# Altium schematic sheet BatGPSTracker.SchDoc
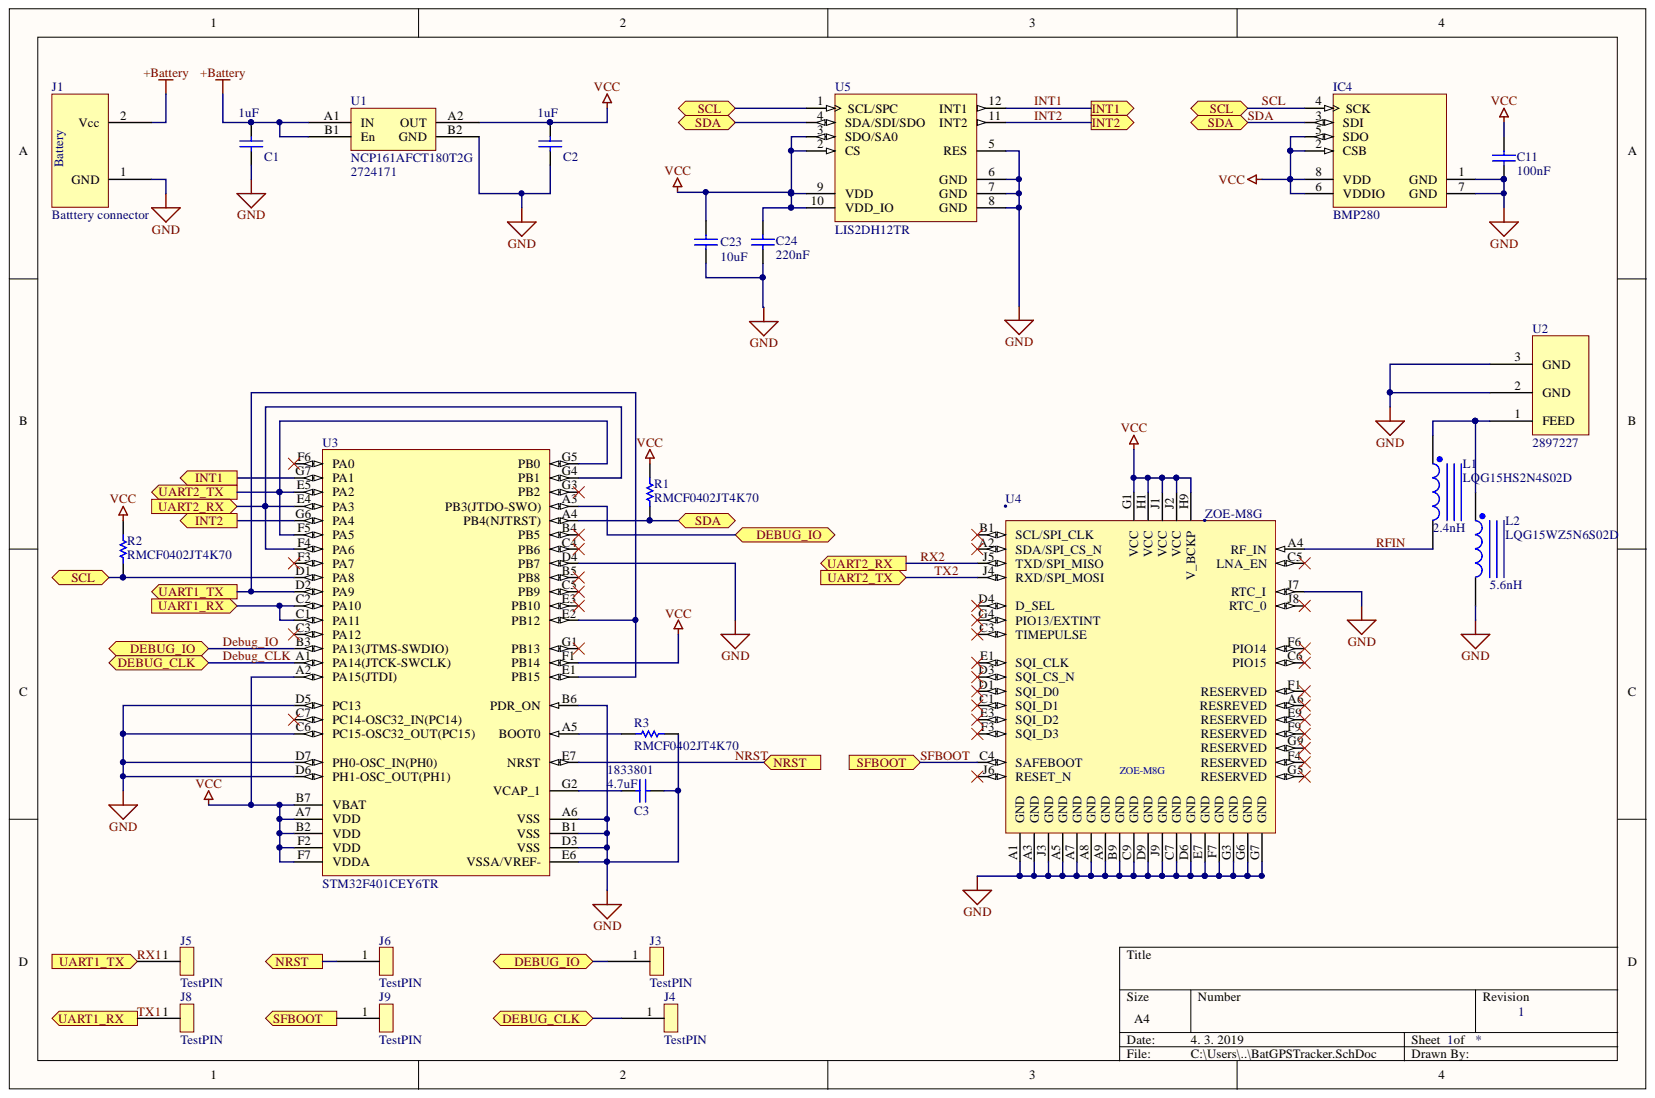
[diagram p0: BatGPSTracker.SchDoc]

## Parts
C1  value 1uF  [CAPC0402(1005)60_L]  (hidden comment: CAP 1uF 10V 0402(1005))
C2  value 1uF  [CAPC0402(1005)60_L]  (hidden comment: CAP 1uF 10V 0402(1005))
C3  value 4.7uF  Supplier Part Number 1: 1833801  [CAPC0402(1005)60_L]  (hidden comment: CAP 4.7uF 16V 0402(1005))
C11  value 100nF  [CAPC0402(1005)60_L]  (hidden comment: CAP 100nF 25V 0402(1005))
C23  value 10uF  [CAPC0402(1005)60_L]  (hidden comment: CAP 10uF 6.3V 0402(1005))
C24  value 220nF  [CAPC0402(1005)60_L]  (hidden comment: CAP 220nF 6.3V 0402(1005))
IC4  BMP280  [BOSC-LGA-8_V]
J1  Batttery connector  [PCBCOMPONENT_1]  (no BOM)
J3  TestPIN  (no BOM)
J4  TestPIN  (no BOM)
J5  TestPIN  (no BOM)
J6  TestPIN  (no BOM)
J8  TestPIN  (no BOM)
J9  TestPIN  (no BOM)
L1  LQG15HS2N4S02D  Inductance: 2.4nH  [INDC1005X55X25ML05T10_A]
L2  LQG15WZ5N6S02D  Inductance: 5.6nH  [INDC1005X55X25ML05T10_A]
R1  RMCF0402JT4K70  [RESC1005X40X25LL10T15]
R2  RMCF0402JT4K70  [RESC1005X40X25LL10T15]
R3  RMCF0402JT4K70  [RESC1005X40X25LL10T15]
U1  NCP161AFCT180T2G  Supplier Part Number 1: 2724171  [BGA4C40P2X2_84X84X60N]
U2  Supplier Part Number 1: 2897227  [W3011]  (hidden comment: W3011)
U3  STM32F401CEY6TR  [STM-WLCSP49-3060X3060DE_V]
U4  ZOE-M8G  [ZOE-M8_ZOE-M8G(Primary)]
U5  LIS2DH12TR  [STM-LGA-12]

## Ports
DEBUG_CLK, DEBUG_IO, INT1, INT2, NRST, SCL, SDA, SFBOOT, UART1_RX, UART1_TX, UART2_RX, UART2_TX

## Nets
+BATTERY: C1.2 J1.2 U1.A1(IN) U1.B1(En)
GND: C1.1 C2.1 C3.2 C11.1 C23.1 C24.1 IC4.1(GND) IC4.7(GND) J1.1 L2.2 R3.1 U1.B2(GND) U2.2 U2.3 U3.A6(VSS) U3.B1(VSS) U3.B6(PDR_ON) U3.C6(PC15-OSC32_OUT(PC15)) U3.D3(VSS) U3.D4(PB7) U3.D5(PC13) U3.D6(PH1-OSC_OUT(PH1)) U3.D7(PH0-OSC_IN(PH0)) U3.E6(VSSA/VREF-) U4.A1(GND) U4.A3(GND) U4.A5(GND) U4.A7(GND) U4.A8(GND) U4.A9(GND) U4.B9(GND) U4.C7(GND) U4.C9(GND) U4.D6(GND) U4.D9(GND) U4.E7(GND) U4.F7(GND) U4.G3(GND) U4.G6(GND) U4.G7(GND) U4.J3(GND) U4.J7(RTC_I) U4.J9(GND) U5.5(RES) U5.6(GND) U5.7(GND) U5.8(GND)
VCC: C2.2 C11.2 C23.2 C24.2 IC4.2(CSB) IC4.5(SDO) IC4.6(VDDIO) IC4.8(VDD) R1.2 R2.2 U1.A2(OUT) U3.A2(PA15(JTDI)) U3.A7(VDD) U3.B2(VDD) U3.B7(VBAT) U3.F1(PB14) U3.F2(VDD) U3.F7(VDDA) U4.G1(VCC) U4.J1(VCC) U4.J2(VCC) U5.2(CS) U5.3(SDO/SA0) U5.9(VDD) U5.10(VDD_IO)
DEBUG_CLK: J4.1 U3.A1(PA14(JTCK-SWCLK)) ; port DEBUG_CLK
DEBUG_IO: J3.1 U3.A3(PB3(JTDO-SWO)) U3.B3(PA13(JTMS-SWDIO)) ; port DEBUG_IO
INT1: U3.G7(PA1) U5.12(INT1) ; port INT1
INT2: U3.G6(PA4) U5.11(INT2) ; port INT2
NRST: J6.1 U3.E7(NRST) ; port NRST
RFIN: L1.2 U4.A4(RF_IN)
RX1: J5.1 U3.D2(PA9) U3.E1(PB15) U3.E2(PB12) ; port UART1_TX
RX2: U3.E4(PA3) U3.F4(PA6) U3.G4(PB1) U4.J5(TXD/SPI_MISO) ; port UART2_RX
SCL: IC4.4(SCK) R2.1 U3.D1(PA8) U5.1(SCL/SPC) ; port SCL
SDA: IC4.3(SDI) R1.1 U3.A4(PB4(NJTRST)) U5.4(SDA/SDI/SDO) ; port SDA
SFBOOT: J9.1 U4.C4(SAFEBOOT) ; port SFBOOT
TX1: J8.1 U3.C1(PA11) U3.C2(PA10) ; port UART1_RX
UART2_TX: U3.E5(PA2) U3.F5(PA5) U3.G5(PB0) U4.J4(RXD/SPI_MOSI) ; port UART2_TX
NetC3_1: C3.1 U3.G2(VCAP_1)
NetL1_1: L1.1 L2.1 U2.1
NetR3_2: R3.2 U3.A5(BOOT0)
TX2:

Not connected: U3 B4(PB5) B5(PB8) C3(PA12) C4(PB6) C5(PB9) C7(PC14-OSC32_IN(PC14)) E3(PB10) F3(PA7) F6(PA0) G1(PB13) G3(PB2); U4 A2(SDA/SPI_CS_N) A6(RESREVED) B1(SCL/SPI_CLK) C1(SQI_D1) C3(TIMEPULSE) C5(LNA_EN) C6(PIO15) D1(SQI_D0) D3(SQI_CS_N) D4(D_SEL) E1(SQI_CLK) E3(SQI_D2) E9(RESERVED) F1(RESERVED) F3(SQI_D3) F4(RESERVED) F6(PIO14) F9(RESERVED) G4(PIO13/EXTINT) G5(RESERVED) G9(RESERVED) H1(VCC) H9(V_BCKP) J6(RESET_N) J8(RTC_0)

## Library data of the parts
C1 C2 = CMP-1034-02118-1: CAP 1uF 10V -20% to +80% 0402 (1005 Metric) Thickness 0.6mm SMD; Case-EIA: 0402; Case-Metric: 1005; Component Kind: Standard; Library Name: Altium Content Vault; Max Thickness: 0.6 mm; Rated Voltage: 10 V; Technology: SMT; Tolerance: -20% to +80%
C3 = CMP-1034-01811-1: CAP 4.7uF 16V ±20% 0402 (1005 Metric) Thickness 0.6mm SMD; Case-EIA: 0402; Case-Metric: 1005; Tolerance: Â±20%; Component Kind: Standard; Library Name: Altium Content Vault; Max Thickness: 0.6 mm; Rated Voltage: 16 V; Supplier 1: Farnell; Technology: SMT
C11 = CMP-1034-02073-1: CAP 100nF 25V ±20% 0402 (1005 Metric) Thickness 0.6mm SMD; Case-EIA: 0402; Case-Metric: 1005; Tolerance: Â±20%; Component Kind: Standard; Library Name: Altium Content Vault; Max Thickness: 0.6 mm; Rated Voltage: 25 V; Technology: SMT
C23 = CMP-1034-02138-1: CAP 10uF 6.3V ±20% 0402 (1005 Metric) Thickness 0.6mm SMD; Case-EIA: 0402; Case-Metric: 1005; Tolerance: Â±20%; Component Kind: Standard; Library Name: Altium Content Vault; Max Thickness: 0.6 mm; Rated Voltage: 6.3 V; Technology: SMT
C24 = CMP-1034-02085-1: CAP 220nF 6.3V -20% to +80% 0402 (1005 Metric) Thickness 0.6mm SMD; Case-EIA: 0402; Case-Metric: 1005; Component Kind: Standard; Library Name: Altium Content Vault; Max Thickness: 0.6 mm; Rated Voltage: 6.3 V; Technology: SMT; Tolerance: -20% to +80%
IC4 = CMP-2000-05076-1: Digital Pressure Sensor, 1.71 to 3.6 V, -40 to 85 degC, 8-Pin LGA, RoHS, Tape and Reel; Manufacturer: Bosch Sensortec; Component Kind: Standard; Datasheet URL: http://datasheet.octopart.com/BMP280-Bosch-datasheet-41546027.pdf; Datasheet Version: Rev. 1.14, 05/2015; Library Name: Altium Content Vault; Manufacturer URL: http://www.bosch-sensortec.com
J1 J3-J6 J8 J9 = Component_1
  J1: Library Name: Battery100mAh.SchLib
  J3-J6 J8 J9: Library Name: DebugPin.SchLib
L1 = CMP-2000-06579-1: Chip Inductor, 2.4 nH, +/- 0.3 nH, 300 mA, -55 to 125 degC, 0402 (1005 Metric), RoHS, Tape and Reel; Manufacturer: MurataElectronics; Core Material: Air; Maximum DC Current: 300mA; Maximum DC Resistance: 150mOhm; Minimum Quality Factor: 8@100MHz; Product Height: 0.55mm; Tolerance: 0.3nH; Type: RFChip; Category: InductorSurfaceMount; Component Kind: Standard; Datasheet URL: http://search.murata.co.jp/Ceramy/image/img/PDF/ENG/L0075S0083LQG15HS.pdf; Datasheet Version: 09/2010; Library Name: Altium Content Vault; Manufacturer URL: http://www.murata.com/; Mounting Technology: SurfaceMount; Package/Case: 0402; Package Description: 2-Pin Surface Mount Device, Body 1 x 0.5 mm; Package Reference: 0402
L2 = CMP-2000-06579-1: Chip Inductor, 5.6 nH, +/- 0.3 nH, 300 mA, -55 to 125 degC, 0402 (1005 Metric), RoHS, Tape and Reel; Manufacturer: MurataElectronics; Core Material: Air; Maximum DC Current: 300mA; Maximum DC Resistance: 150mOhm; Minimum Quality Factor: 8@100MHz; Product Height: 0.55mm; Tolerance: 0.3nH; Type: RFChip; Category: InductorSurfaceMount; Component Kind: Standard; Datasheet URL: http://search.murata.co.jp/Ceramy/image/img/PDF/ENG/L0075S0083LQG15HS.pdf; Datasheet Version: 09/2010; Library Name: Altium Content Vault; Manufacturer URL: http://www.murata.com/; Mounting Technology: SurfaceMount; Package/Case: 0402; Package Description: 2-Pin Surface Mount Device, Body 1 x 0.5 mm; Package Reference: 0402
R1-R3 = CMP-2000-06988-1: Chip Resistor, 4.7 KOhm, +/- 5%, 0.063 W, -55 to 155 degC, 0402 (1005 Metric), RoHS, Tape and Reel; Manufacturer: Stackpole-Electronics-Inc.; Power (Watts): 0.063W,1/16W; Resistance (Ohms): 4.7k; Temperature Coefficient: ±100ppm/°C; Category: Resistors; Component Kind: Standard; Composition: ThickFilm; Datasheet URL: https://www.seielect.com/Catalog/SEI-RMCF_RMCP.pdf; Datasheet Version: 01/2017; Family: ChipResistor-SurfaceMount; Features: AutomotiveAEC-Q200; Height: 0.016"(0.40mm); Library Name: Altium Content Vault; Manufacturer URL: https://www.seielect.com/; Mounting Technology: SurfaceMount; Number of Terminations: 2; Operating Temperature: -55°C~155°C; Package / Case: 0402(1005Metric); Package Description: 2-Pin Surface Mount Device, Body 1 x 0.5 mm; Package Reference: 0402; Packaging: Digi-Reel®; Series: RMCF; Size / Dimension: 0.039"Lx0.020"W(1.00mmx0.50mm); Supplier Device Package: 0402; Tolerance: ±5%
U1 = Component_1: 1.8V Regulator; Component Kind: Standard; Library Name: NCP16.SchLib; Supplier 1: Farnell
U2 = Component_1: GPS Chip antenna; Component Kind: Standard; Library Name: W3011.SchLib; Supplier 1: Farnell
U3 = CMP-0243-00142-1: ARM Cortex-M4 32-bit MCU+FPU, 512 KB Flash, 96 KB Internal RAM, 36 I/Os, 49-Pin WLCSP, -40 to 85 degC, Tape and Reel; FLASH Size (Prog) (kB): 512; I/Os (High Current): 36; Internal RAM Size (kB): 96; Operating Frequency(F) (Processor speed) (MHz): 84; Supply Voltage(Vcc) min (V): 1.7; Component Kind: Standard; ComponentLink1Description: Manufacturer URL; ComponentLink1URL: http://www.st.com/; ComponentLink2Description: Datasheet; ComponentLink2URL: http://www.st.com/st-web-ui/static/active/en/resource/technical/document/datasheet/DM00102166.pdf; Core: ARM Cortex-M4; DatasheetVersion: Rev. 3; Library Name: Altium Content Vault; Mounting Technology: Surface Mount; Package Description: WLCSP49-3060X3060DE; Package Reference: 49-Ball Wafer Level Chip Scale Package, 3.029 x 3.029 mm Body, 0.4 mm Pitch; Packing: Tape and Reel
U4 = ZOE-M8G: M8 Concurrent Gnss Module; MF: u-blox; Availability: Unavailable; Component Kind: Standard; Library Name: ZOE-M8G.SchLib; MP: ZOE-M8G; Package: SMD-51 u-blox; Price: None; SnapEDA_Link: https://www.snapeda.com/parts/ZOE-M8G/u-blox/view-part/?ref=snap
U5 = CMP-2000-05369-1: MEMS Digital Output Motion Sensor: Ultra-Low-Power High-Performance 3-Axis "Femto" Accelerometer, 1.71 to 3.6 V, -40 to 85 degC, 12-Pin LGA, RoHS, Tape and Reel; Manufacturer: STMicroelectronics; Frequency Response Range: 0→400(SCL)kHz,Maximumof10(SPI)MHz; Interface Type: I2C,SPI; Maximum Frequency Response: 10(SPI)MHz,400(SCL)kHz; Maximum Operating Supply Voltage: 3.6V; Minimum Frequency Response: 0(SCL)kHz; Minimum Operating Supply Voltage: 1.71V; Number of Axis: 3; Operating Supply Voltage Range: 1.71→3.6V; Sensor Range: ±16g,±2g,±4g,±8g; Component Kind: Standard; Datasheet URL: http://www.st.com/st-web-ui/static/active/en/resource/technical/document/datasheet/DM00091513.pdf; Datasheet Version: Dec-15; Dimensions: 2.15x2.15x1mm; Height: 1mm; Length: 2.15mm; Library Name: Altium Content Vault; Manufacturer URL: http://www.st.com/; Maximum Operating Temperature: +85degC; Minimum Operating Temperature: -40degC; Mounting Type: SurfaceMount; Operating Temperature Range: -40→+85degC; Package Description: 12-Pin Land Grid Array Package, Body 2 x 2 mm, Pitch 0.5 mm; Package Reference: LGA-12; Package Type: LGA; Pin Count: 12; Technology: Digital; Width: 2.15mm
